# Altium schematic sheet led4.SchDoc
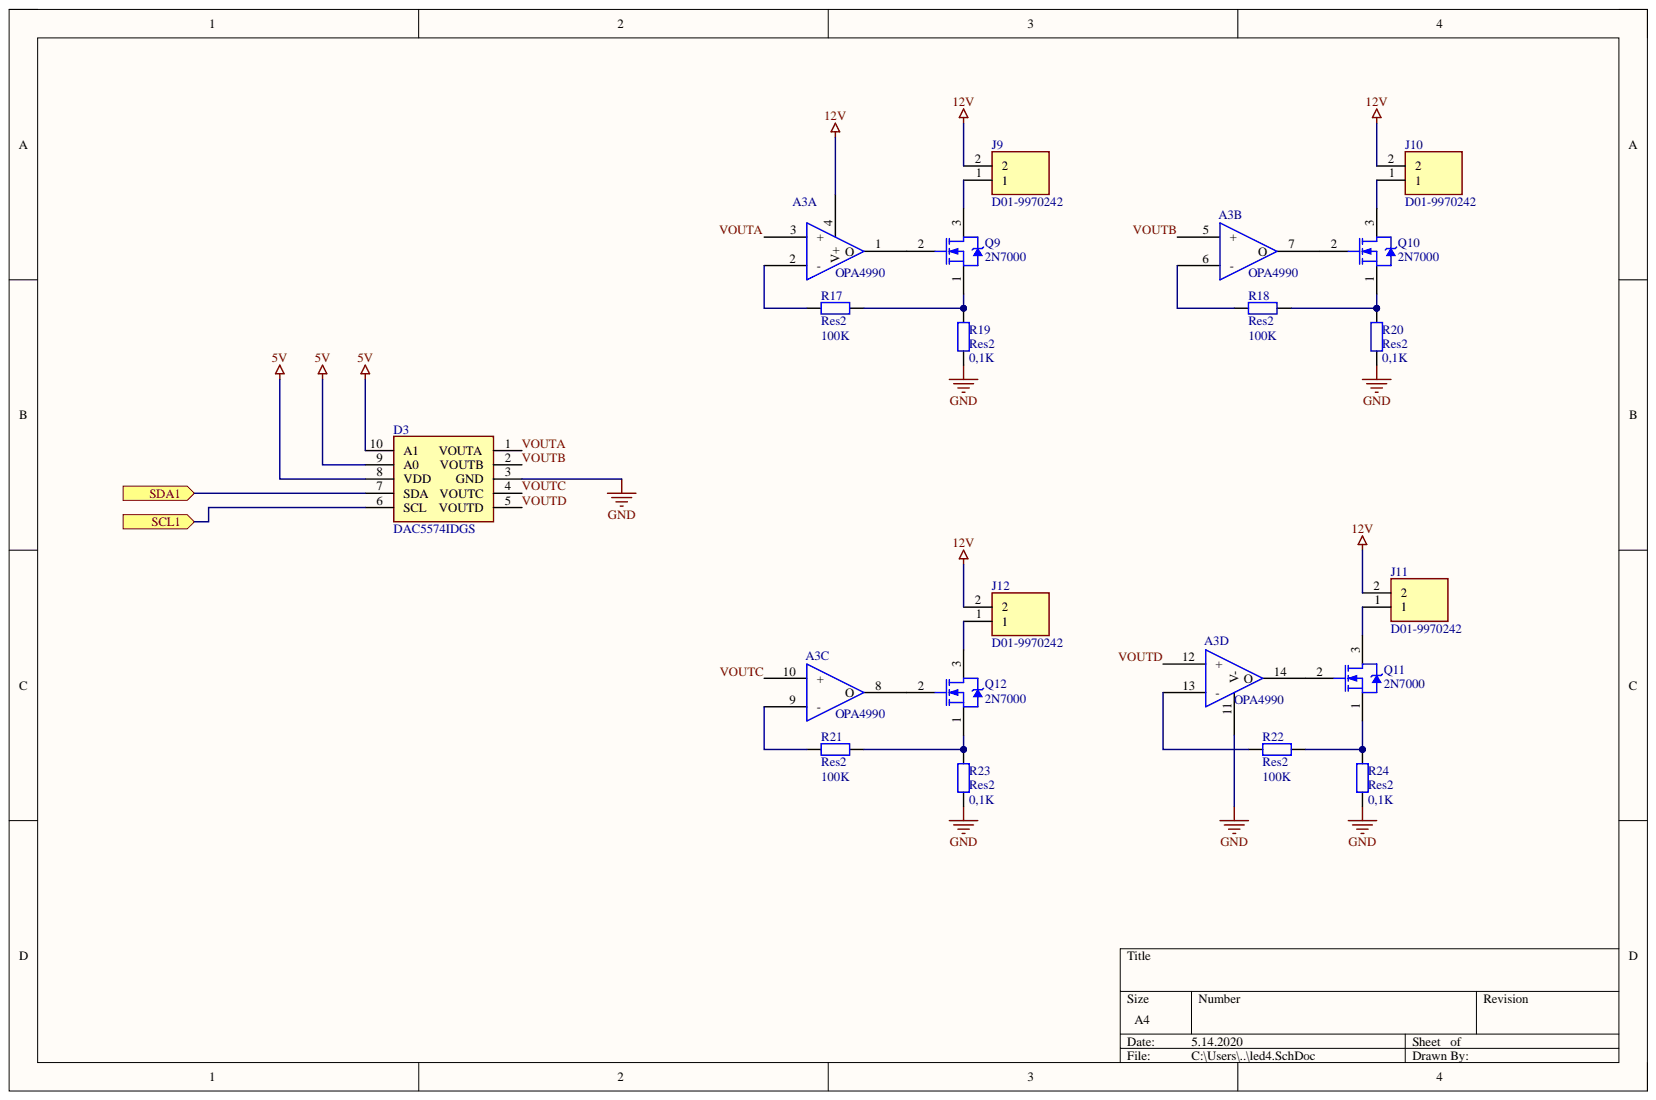
[diagram p0: led4.SchDoc]

## Parts
A3  OPA4990  [SOIC127P600X175-14N]  (parts A,B,C,D)
D3  DAC5574IDGS  [SOP50P490X110-10N]
J9  D01-9970242  [HDR1X2]
J10  D01-9970242  [HDR1X2]
J11  D01-9970242  [HDR1X2]
J12  D01-9970242  [HDR1X2]
Q9  2N7000  [ONSC-TO-92-3-29-11]
Q10  2N7000  [ONSC-TO-92-3-29-11]
Q11  2N7000  [ONSC-TO-92-3-29-11]
Q12  2N7000  [ONSC-TO-92-3-29-11]
R17  Res2  value 100K  [6-0805_L]
R18  Res2  value 100K  [6-0805_L]
R19  Res2  value 0,1K  [C1210]
R20  Res2  value 0,1K  [C1210]
R21  Res2  value 100K  [6-0805_L]
R22  Res2  value 100K  [6-0805_L]
R23  Res2  value 0,1K  [C1210]
R24  Res2  value 0,1K  [C1210]

## Ports
SCL1, SDA1

## Nets
5V: D3.8(VDD) D3.9(A0) D3.10(A1)
12V: A3.4(V+) J9.2 J10.2 J11.2 J12.2
GND: A3.11(V-) D3.3(GND) R19.1 R20.1 R23.1 R24.1
SCL1: D3.6(SCL) ; port SCL1
SDA1: D3.7(SDA) ; port SDA1
VOUTA_4: A3.3(+) D3.1(VOUTA)  [also labelled VOUTA]
VOUTB_4: A3.5(+) D3.2(VOUTB)  [also labelled VOUTB]
VOUTC_4: A3.10(+) D3.4(VOUTC)  [also labelled VOUTC]
VOUTD_4: A3.12(+) D3.5(VOUTD)  [also labelled VOUTD]
NetA3_1: A3.1(O) Q9.2
NetA3_2: A3.2(-) R17.1
NetA3_6: A3.6(-) R18.1
NetA3_7: A3.7(O) Q10.2
NetA3_8: A3.8(O) Q12.2
NetA3_9: A3.9(-) R21.1
NetA3_13: A3.13(-) R22.1
NetA3_14: A3.14(O) Q11.2
NetJ9_1: J9.1 Q9.3
NetJ10_1: J10.1 Q10.3
NetJ11_1: J11.1 Q11.3
NetJ12_1: J12.1 Q12.3
NetQ9_1: Q9.1 R17.2 R19.2
NetQ10_1: Q10.1 R18.2 R20.2
NetQ11_1: Q11.1 R22.2 R24.2
NetQ12_1: Q12.1 R21.2 R23.2

## Library data of the parts
D3 = DAC5574IDGS: Integrated Circuit; Manufacturer_Name: Texas Instruments; Component Kind: Standard; Datasheet Link: http://www.ti.com/lit/gpn/dac5574; Height: 1.1mm; Manufacturer_Part_Number: DAC5574IDGS; Mouser Part Number: 595-DAC5574IDGS; Mouser Price/Stock: https://www.mouser.com/Search/Refine.aspx?Keyword=595-DAC5574IDGS; RS Part Number: 6621533P; RS Price/Stock: http://uk.rs-online.com/web/p/products/6621533P
J9-J12 = D01-9970242: Connector; Manufacturer_Name: HARWIN; Component Kind: Standard; Datasheet Link: https://componentsearchengine.com/Datasheets/1/D01-9970242.pdf; Height: 4.25mm; Library Name: SamacSys2.SchLib; Manufacturer_Part_Number: D01-9970242; Mouser Part Number: 855-D01-9970242; Mouser Price/Stock: https://www.mouser.com/Search/Refine.aspx?Keyword=855-D01-9970242
Q9-Q12 = CMP-1058-00936-1: Small Signal MOSFET, 200 mA, 60 V, N-Channel, 3-Pin TO-92, Bulk Box; Manufacturer: On Semiconductor; Channel Polarity: N Channel; Ciss Typ (pF): 60; Configuration: Single; Coss Typ (pF): 25; Crss Typ (pF): 5; ID Max (A): 0.2; PD Max (W): 0.35; VGS Max (V): 20; VGS(th) Max (V): 3; Component Kind: Standard; ComponentLink1Description: Manufacturer URL; ComponentLink1URL: http://www.onsemi.com/; ComponentLink2Description: Datasheet; ComponentLink2URL: http://www.onsemi.com/pub_link/Collateral/2N7000-D.PDF; ComponentLink3Description: Package Specification; ComponentLink3URL: http://www.onsemi.cn/pub_link/Collateral/2N6426-D.PDF; DatasheetVersion: Rev. 8; Library Name: Components - 24.04.20 - 07.58.SchLib; Mounting Technology: Through Hole; Package Type: TO-92; PackageDescription: 3-Pin Transistor Outline, Body 4.825 x 3.685 mm, Pitch 1.27 mm; PackageReference: TO-92-3-29-11_AM_SL; PackageVersion: Rev. AM; Packing: Bulk Box; PartNumber: 2N7000; V(BR)DSS Min (V): 60
R17-R24 = Res2: Resistor; Component Kind: Standard; LatestRevisionDate: 17-Jul-2002; LatestRevisionNote: Re-released for DXP Platform.; Library Name: Miscellaneous Devices.IntLib; PackageDescription: Axial Device, Thru-Hole; 2 Leads; 0.4 in Pin Spacing; PackageReference: AXIAL-0.4; Published: 8-Jun-2000; Signal Integrity: Res; Simulation: RESISTOR; Publisher: Altium Limited
A3 = OPA4990: Component Kind: Standard; Library Name: Kalibracija.SchLib
